# Altium schematic sheet SNP_BoardSupport.SchDoc(SNP_BoardSupport)
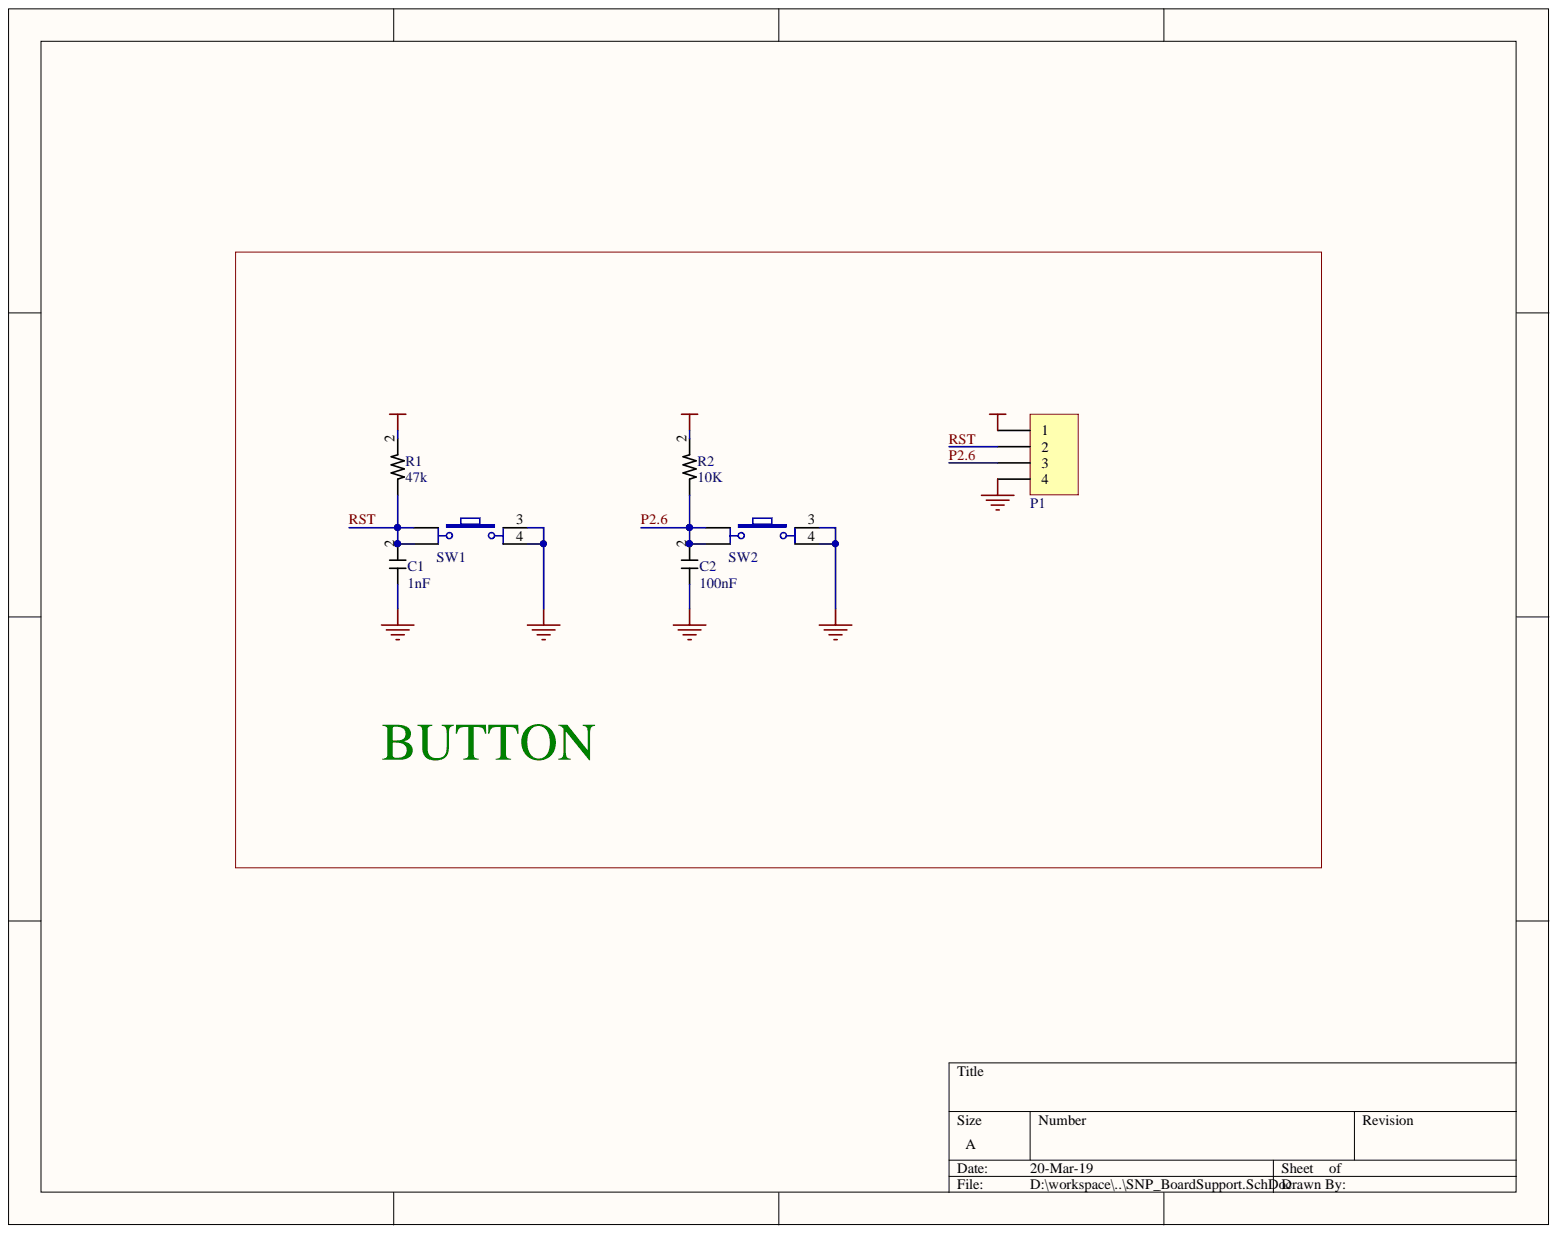
[diagram p0: SNP_BoardSupport.SchDoc]

## Parts
C1  value 1nF  [C0805]  (hidden comment: Cap Non Pol)
C2  value 100nF  [C0805]  (hidden comment: Cap Non Pol)
P1  Header 4  [JP4]  (value not drawn)
R1  value 47k  [R0805]  (hidden comment: Resistor)
R2  value 10K  [R0805]  (hidden comment: Resistor)
SW1  SW  [SW4 DIP]
SW2  SW  [SW4 DIP]

## Nets
GND: C1.1 C2.1 P1.4 SW1.3 SW1.4 SW2.3 SW2.4
VCC: P1.1 R1.2 R2.2
P2.6: C2.2 P1.3 R2.1 SW2.1 SW2.2
RST: C1.2 P1.2 R1.1 SW1.1 SW1.2

## Library data of the parts
C1 C2 = Cap Non Pol: Non Polarised Capacitor; Library Name: C17_MSP430G2553.SchLib; Component Kind: Standard
P1 = Header 4: Header, 4-Pin; Library Name: PIF_Library.IntLib; LatestRevisionNote: Re-released for DXP Platform.; LatestRevisionDate: 17-Jul-2002; Component Kind: Standard; Publisher: Altium Limited
SW1 SW2 = SW4: Switch Button 4 Pins; Library Name: C17_MSP430G2553.SchLib; Component Kind: Standard
R1 R2 = Resistor: Library Name: C17_MSP430G2553.SchLib; Description: Resistor; Component Kind: Standard
